# Altium schematic sheet GenesysHat - System.SchDoc
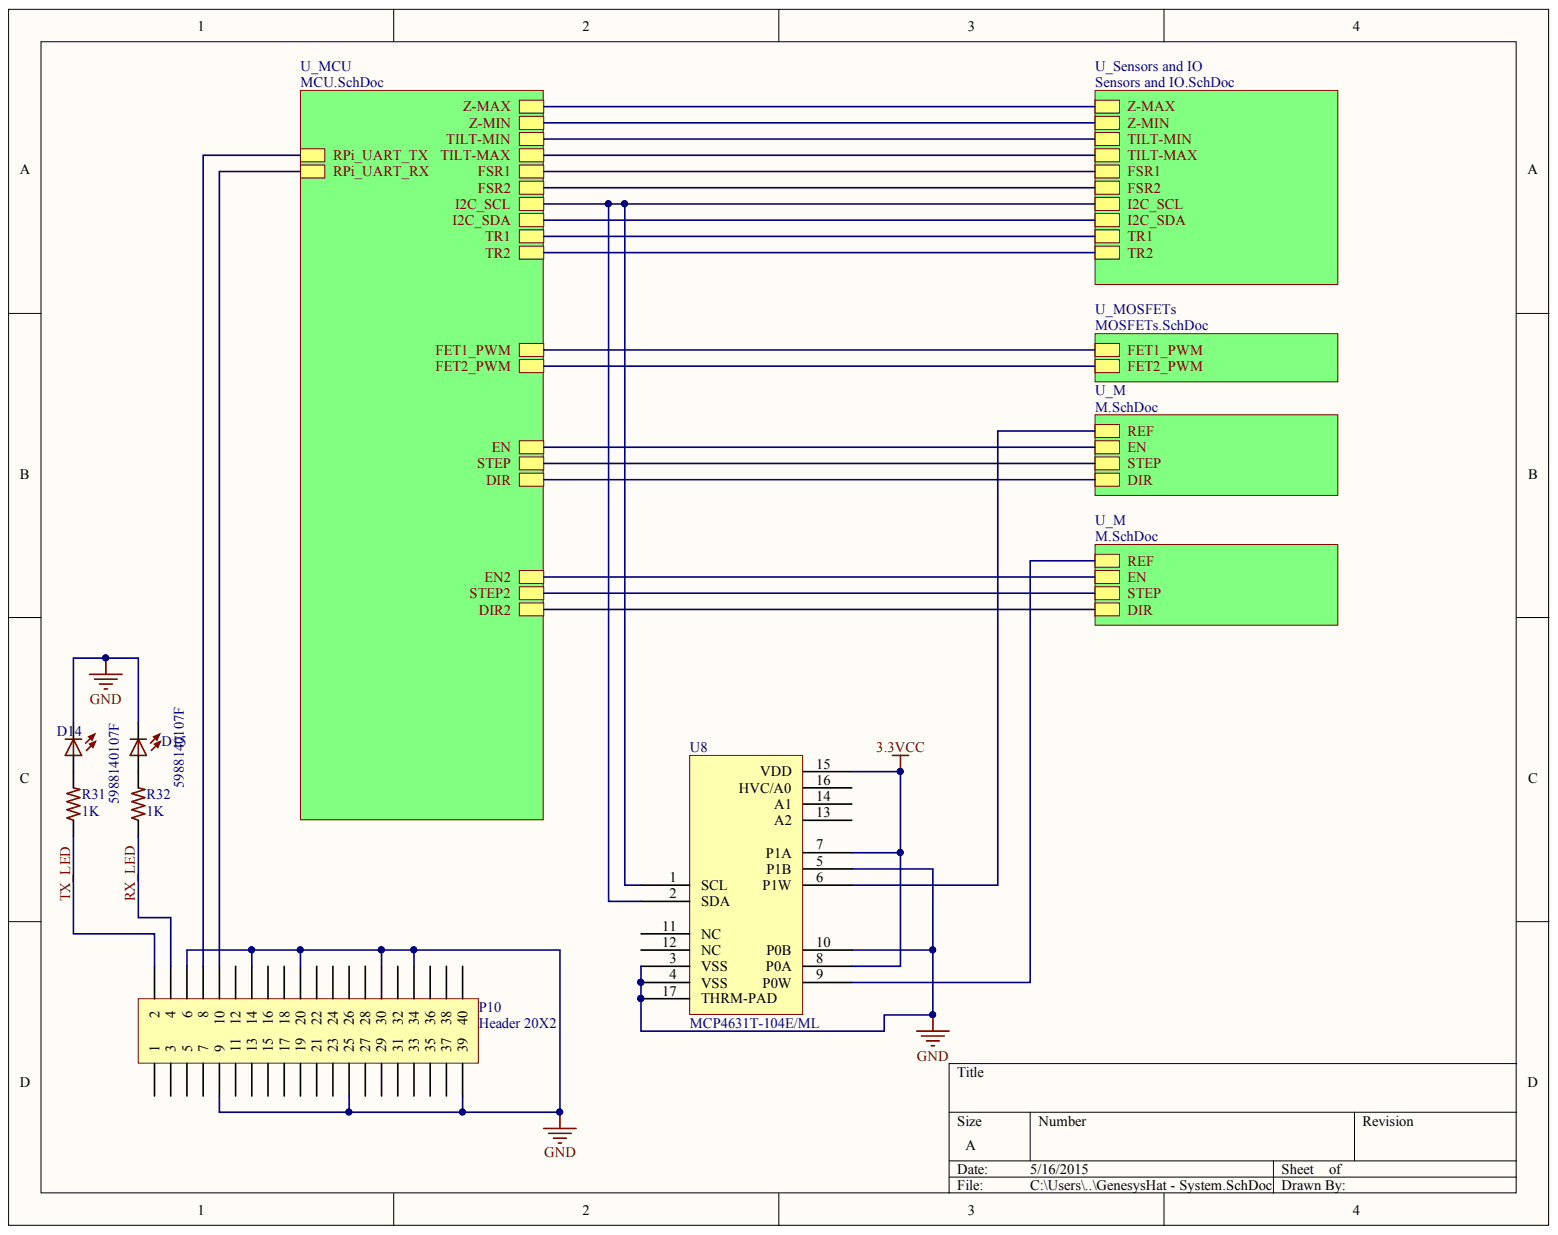
[diagram p0: GenesysHat - System.SchDoc]

## Parts
D14  5988140107F  [LED2012120]
D15  5988140107F  [LED2012120]
P10  Header 20X2  [HDR2X20]
R31  1K  [R0603]
R32  1K  [R0603]
U8  MCP4631T-104E/ML  [MCP4631T-104E/ML]

## Nets
3.3VCC: U8.7(P1A) U8.8(P0A) U8.15(VDD)
GND: D14.C D15.C P10.6 P10.9 P10.14 P10.20 P10.25 P10.30 P10.34 P10.39 U8.3(VSS) U8.4(VSS) U8.5(P1B) U8.10(P0B) U8.17(THRM-PAD)
RX_LED: P10.4 R32.1
TX_LED: P10.2 R31.1
NetD14_A: D14.A R31.2
NetD15_A: D15.A R32.2
NetP10_1: P10.1
NetP10_3: P10.3
NetP10_5: P10.5
NetP10_7: P10.7
NetP10_8: P10.8
NetP10_10: P10.10
NetP10_11: P10.11
NetP10_12: P10.12
NetP10_13: P10.13
NetP10_15: P10.15
NetP10_16: P10.16
NetP10_17: P10.17
NetP10_18: P10.18
NetP10_19: P10.19
NetP10_21: P10.21
NetP10_22: P10.22
NetP10_23: P10.23
NetP10_24: P10.24
NetP10_26: P10.26
NetP10_27: P10.27
NetP10_28: P10.28
NetP10_29: P10.29
NetP10_31: P10.31
NetP10_32: P10.32
NetP10_33: P10.33
NetP10_35: P10.35
NetP10_36: P10.36
NetP10_37: P10.37
NetP10_38: P10.38
NetP10_40: P10.40
NetU8_1: U8.1(SCL) U8.2(SDA)
NetU8_6: U8.6(P1W)
NetU8_9: U8.9(P0W)
NetU8_11: U8.11(NC)
NetU8_12: U8.12(NC)
NetU8_13: U8.13(A2)
NetU8_14: U8.14(A1)
NetU8_16: U8.16(HVC/A0)
DIR:
EN:
FET1_PWM:
FET2_PWM:
FSR1:
FSR2:
I2C_SDA:
STEP:
TILT-MAX:
TILT-MIN:
TR1:
TR2:
Z-MAX:
Z-MIN:

## Library data of the parts
D14 D15 = 5988140107F: LED 0805 Yellow; LastRevisionNote: ImportComponents; Component Kind: Standard; LastRevisionDate: 23-Apr-2014; Library Name: Tigershark3D.SchLib; Published: 23-Apr-2014; VALUE: 5988140107F; Publisher: UltiMachine
P10 = Header 20X2: Header, 20-Pin, Dual row; Component Kind: Standard; LatestRevisionDate: 17-Jul-2002; LatestRevisionNote: Re-released for DXP Platform.; Library Name: Miscellaneous Connectors.IntLib; Signal Integrity: Connector; Publisher: Altium Limited
R31 R32 = ERJ-3EKF1001V: RES 0603 1K 100mW 1%; LastRevisionNote: ImportComponents; Component Kind: Standard; LastRevisionDate: 23-Apr-2014; Library Name: Tigershark3D.SchLib; ManufacturerPartNumber: ERJ-3EKF1001V; Published: 23-Apr-2014; Signal Integrity: Model Name; Simulation: Model Name; VALUE: 1K; Publisher: UltiMachine
U8 = MCP4631T-104E/ML: IC DGTL POT 100K 129TAPS 16-QF; MFR: Microchip; Component Kind: Standard; Digikey #: MCP4631T-104E/MLCT-ND; MFR #: MCP4631T-104E/ML; Mounting Type: SMT QFN 16; Package: QFN 16; Published Date: May 2015; Library Name: GenesysHat.SchLib
